# Altium schematic sheet KC1_LCD.SchDoc(KC1_LCD)
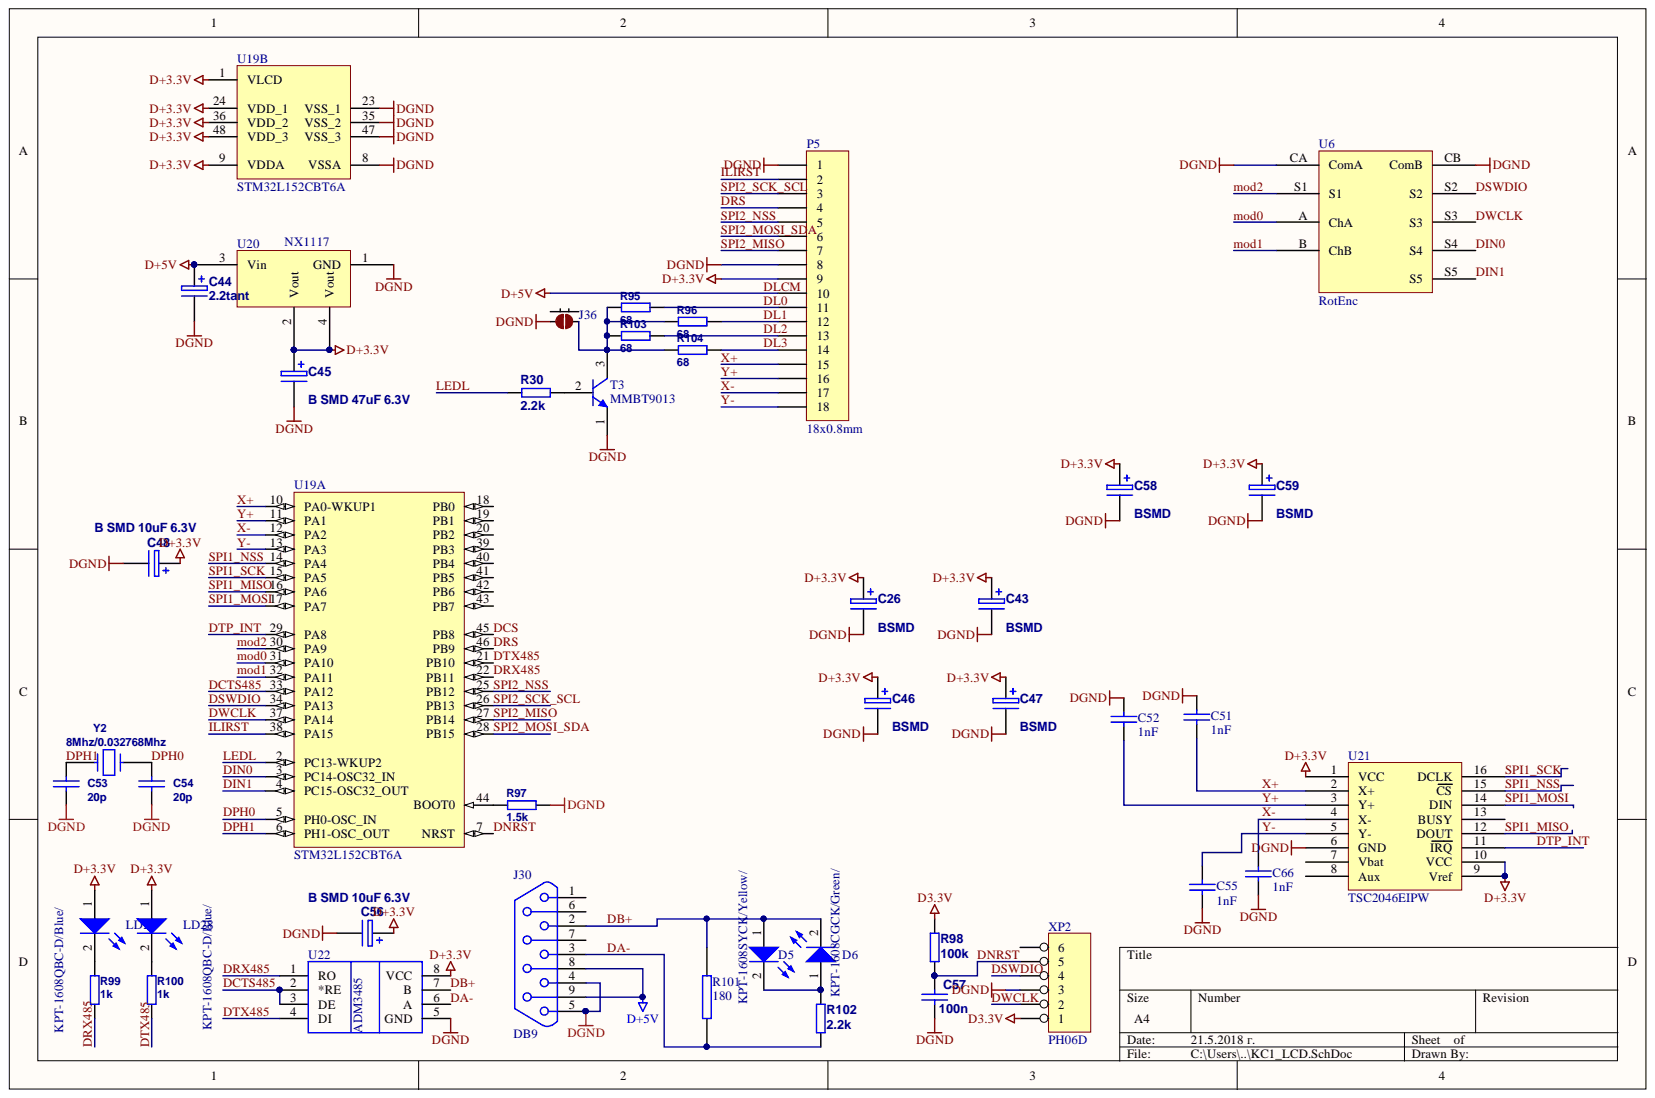
[diagram p0: KC1_LCD.SchDoc]

## Parts
C26  BSMD  [0603C]
C43  BSMD  [0603C]
C44  2.2tant  [1210p]
C45  B SMD 47uF 6.3V  [1210p]
C46  BSMD  [0603C]
C47  BSMD  [0603C]
C48  B SMD 10uF 6.3V  [1210p]
C51  1nF  [0603C]
C52  1nF  [0603C]
C53  20p  [0805.PRT_0805.PRT]
C54  20p  [0805.PRT_0805.PRT]
C55  1nF  [0603C]
C56  B SMD 10uF 6.3V  [1210p]
C57  100n  [0805.PRT_0805.PRT]
C58  BSMD  [1210p]
C59  BSMD  [1210p]
C66  1nF  [0603C]
D5  KPT-1608SYCK/Yellow/  [0603D]
D6  KPT-1608CGCK/Green/  [0603D]
J30  DB9  [FCI_D09S24A4GV00LF]
J36  2 way net solder junction - Normally Open  [2waySolder_NO]  (value not drawn)  (no BOM)
LD27  KPT-1608QBC-D/Blue/  [0603D]
LD28  KPT-1608QBC-D/Blue/  [0603D]
P5  18x0.8mm  [LCD18x0.8mm]
R30  2.2k  [0805R]
R95  68  [0805.PRT_0805.PRT]
R96  68  [0805.PRT_0805.PRT]
R97  1.5k  [1206R]
R98  100k  [0805.PRT_0805.PRT]
R99  1k  [0805R]
R100  1k  [0805R]
R101  180  [0805.PRT_0805.PRT]
R102  2.2k  [0805R]
R103  68  [0805.PRT_0805.PRT]
R104  68  [0805.PRT_0805.PRT]
T3  MMBT9013  [SOT-23-BC846-850-856-860]
U6  RotEnc  [RotEnc5But]
U19  STM32L152CBT6A  [STM-LQFP48_N]  (parts A,B)
U20  NX1117  [sot-223b]
U21  TSC2046EIPW  [tssop16a]
U22  ADM3485  [so-8]
XP2  PH06D  [sip-6]
Y2  8Mhz/0.032768Mhz  [XTAL-MINI]

## Nets
D3.3V: R98.1 XP2.1
D+3.3V: C26.1 C43.1 C45.1 C46.1 C47.1 C48.1 C56.1 C58.1 C59.1 LD27.1 LD28.1 P5.9 U19.1(VLCD) U19.9(VDDA) U19.24(VDD_1) U19.36(VDD_2) U19.48(VDD_3) U20.2(Vout) U20.4(Vout) U21.1(VCC) U21.9(Vref) U21.10(VCC) U22.8(VCC)
DGND: C26.2 C43.2 C44.2 C45.2 C46.2 C47.2 C48.2 C51.1 C52.1 C53.2 C54.2 C55.2 C56.2 C57.2 C58.2 C59.2 C66.2 J30.4 J30.5 J36.2 P5.1 P5.8 R97.2 T3.1 U6.CA(ComA) U6.CB(ComB) U19.8(VSSA) U19.23(VSS_1) U19.35(VSS_2) U19.47(VSS_3) U20.1(GND) U21.6(GND) U22.5(GND) XP2.3
DA-: J30.3 R101.1 R102.1 U22.6(A)
DB+: D5.1 D6.2 J30.2 R101.2 U22.7(B)
DCS: U19.45(PB8)
DCTS485: U19.33(PA12) U22.2(*RE) U22.3(DE)
DIN0: U6.S4 U19.3(PC14-OSC32_IN)
DIN1: U6.S5 U19.4(PC15-OSC32_OUT)
DL0: P5.11 R95.2
DL1: P5.12 R96.2
DL2: P5.13 R103.2
DL3: P5.14 R104.2
DLCM: C44.1 J30.8 J30.9 P5.10 U20.3(Vin)
DNRST: C57.1 R98.2 U19.7(NRST) XP2.5
DPH0: C54.1 U19.5(PH0-OSC_IN) Y2.2
DPH1: C53.1 U19.6(PH1-OSC_OUT) Y2.1
DRS: P5.4 U19.46(PB9)
DRX485: R99.2 U19.22(PB11) U22.1(RO)
DSWDIO: U6.S2 U19.34(PA13) XP2.4
DTP_INT: U19.29(PA8) U21.11(IRQ)
DTX485: R100.2 U19.21(PB10) U22.4(DI)
DWCLK: U6.S3 U19.37(PA14) XP2.2
ILIRST: P5.2 U19.38(PA15)
LEDL: R30.2 U19.2(PC13-WKUP2)
MOD0: U6.A(ChA) U19.31(PA10)
MOD1: U6.B(ChB) U19.32(PA11)
MOD2: U6.S1 U19.30(PA9)
SPI1_MISO: U19.16(PA6) U21.12(DOUT)
SPI1_MOSI: U19.17(PA7) U21.14(DIN)
SPI1_NSS: U19.14(PA4) U21.15(CS)
SPI1_SCK: U19.15(PA5) U21.16(DCLK)
SPI2_MISO: P5.7 U19.27(PB14)
SPI2_MOSI_SDA: P5.6 U19.28(PB15)
SPI2_NSS: P5.5 U19.25(PB12)
SPI2_SCK_SCL: P5.3 U19.26(PB13)
X+: C51.2 P5.15 U19.10(PA0-WKUP1) U21.2(X+)
X-: C66.1 P5.17 U19.12(PA2) U21.4(X-)
Y+: C52.2 P5.16 U19.11(PA1) U21.3(Y+)
Y-: C55.1 P5.18 U19.13(PA3) U21.5(Y-)
NetD5_2: D5.2 D6.1 R102.2
NetJ36_1: J36.1 R95.1 R96.1 R103.1 R104.1 T3.3
NetLD27_2: LD27.2 R99.1
NetLD28_2: LD28.2 R100.1
NetR30_1: R30.1 T3.2
NetR97_1: R97.1 U19.44(BOOT0)

Not connected: J30 1 6 7; U19 18(PB0) 19(PB1) 20(PB2) 39(PB3) 40(PB4) 41(PB5) 42(PB6) 43(PB7); U21 7(Vbat) 8(Aux) 13(BUSY); XP2 6

## Library data of the parts
C26 C43-C48 C56 C58 C59 = ELECTRO1: Electrolytic Capacitor; Component Kind: Standard
C51-C55 C57 C66 = CAP: Capacitor; Component Kind: Standard
D5 D6 LD27 LD28 = LED2: Typical RED, GREEN, YELLOW, AMBER GaAs LED; Component Kind: Standard; Library Name: Miscellaneous Devices.IntLib; Published: 8-Jun-2000; Revision: July-2002: Re-released for DXP Platform.; Publisher: Altium Limited
J36 = 2waySolderNO: ComponentLink1Description: Source; ComponentLink1URL: http://www.edatools.cz/addons; Description: 2 way net solder junction - Normally Open; Library Name: NetTieLib.IntLib
P5 = Header 18: Header, 18-Pin; Component Kind: Standard; LatestRevisionDate: 17-Jul-2002; LatestRevisionNote: Re-released for DXP Platform.; Library Name: Miscellaneous Connectors.IntLib; Signal Integrity: Connector; Publisher: Altium Limited
R30 R95-R98 R102-R104 = RES: Component Kind: Standard
R99 R100 = RESMIN: Component Kind: Standard
R101 = RES2: Component Kind: Standard
T3 = NPN: NPN Bipolar Transistor; Code - JEDEC: TO-226-AA; Component Kind: Standard; Library Name: Miscellaneous Devices.IntLib; Published: 8-Jun-2000; Revision: July-2002: Re-released for DXP Platform.; Signal Integrity: NPN; Simulation: NPN; Publisher: Altium Limited
U19 = STM32L152CBT6A: ARM Cortex-M3 32-bit MCU, 128 KB Flash, 16 KB Internal RAM, 37 I/Os, 48-pin LQFP, -40 to 85 degC, Tray; D/A Converter: 2x12-bit (2 Channel); Data E2PROM nom (B): 4096; FLASH Size (Prog) (kB): 128; I/Os (High Current): 37; Internal RAM Size (kB): 16; Operating Frequency(F) (Processor speed) (MHz): 32; Supply Voltage(Vcc) min (V): 1.65; Component Kind: Standard; ComponentLink1Description: Manufacturer URL; ComponentLink1URL: http://www.st.com/web/en/home.html; ComponentLink2Description: Datasheet; ComponentLink2URL: http://www.st.com/st-web-ui/static/active/en/resource/technical/document/datasheet/CD00277537.pdf; ComponentLink3Description: Package Specification; ComponentLink3URL: http://www.st.com/st-web-ui/static/active/en/resource/technical/document/datasheet/DM00058181.pdf; Core: ARM Cortex-M3; DatasheetVersion: Rev. 8; Library Name: STMicroelectronics STM32 L1.IntLib; Mounting Technology: Surface Mount; PackageDescription: 48-Pin Low Profile Quad Flat Package, 7 x 7 mm Body, 0.5 mm Pitch; PackageReference: LQFP48; PackageVersion: Rev. 6, 06/2013; Packing: Tray; PartNumber: STM32L152CBT6A; Supplier 1: Mouser; Supplier Part Number 1: N/A
U20 = LM7824: Component Kind: Standard; Library Name: StabsRu.SCHLIB
U21 = TSC2046: Farnel 1755601; Component Kind: Standard; Library Name: CPUs.SCHLIB
U22 = ADM485: Component Kind: Standard
XP2 = HEADER 6: Component Kind: Standard
Y2 = CRYSTAL: Component Kind: Standard; Description: Crystal
J30 = DB9: Component Kind: Standard
U6 = RotEnc: Component Kind: Standard; Library Name: StabsRu.SCHLIB
